# Altium schematic sheet 3_AVIDEO.SchDoc(3_AVIDEO)
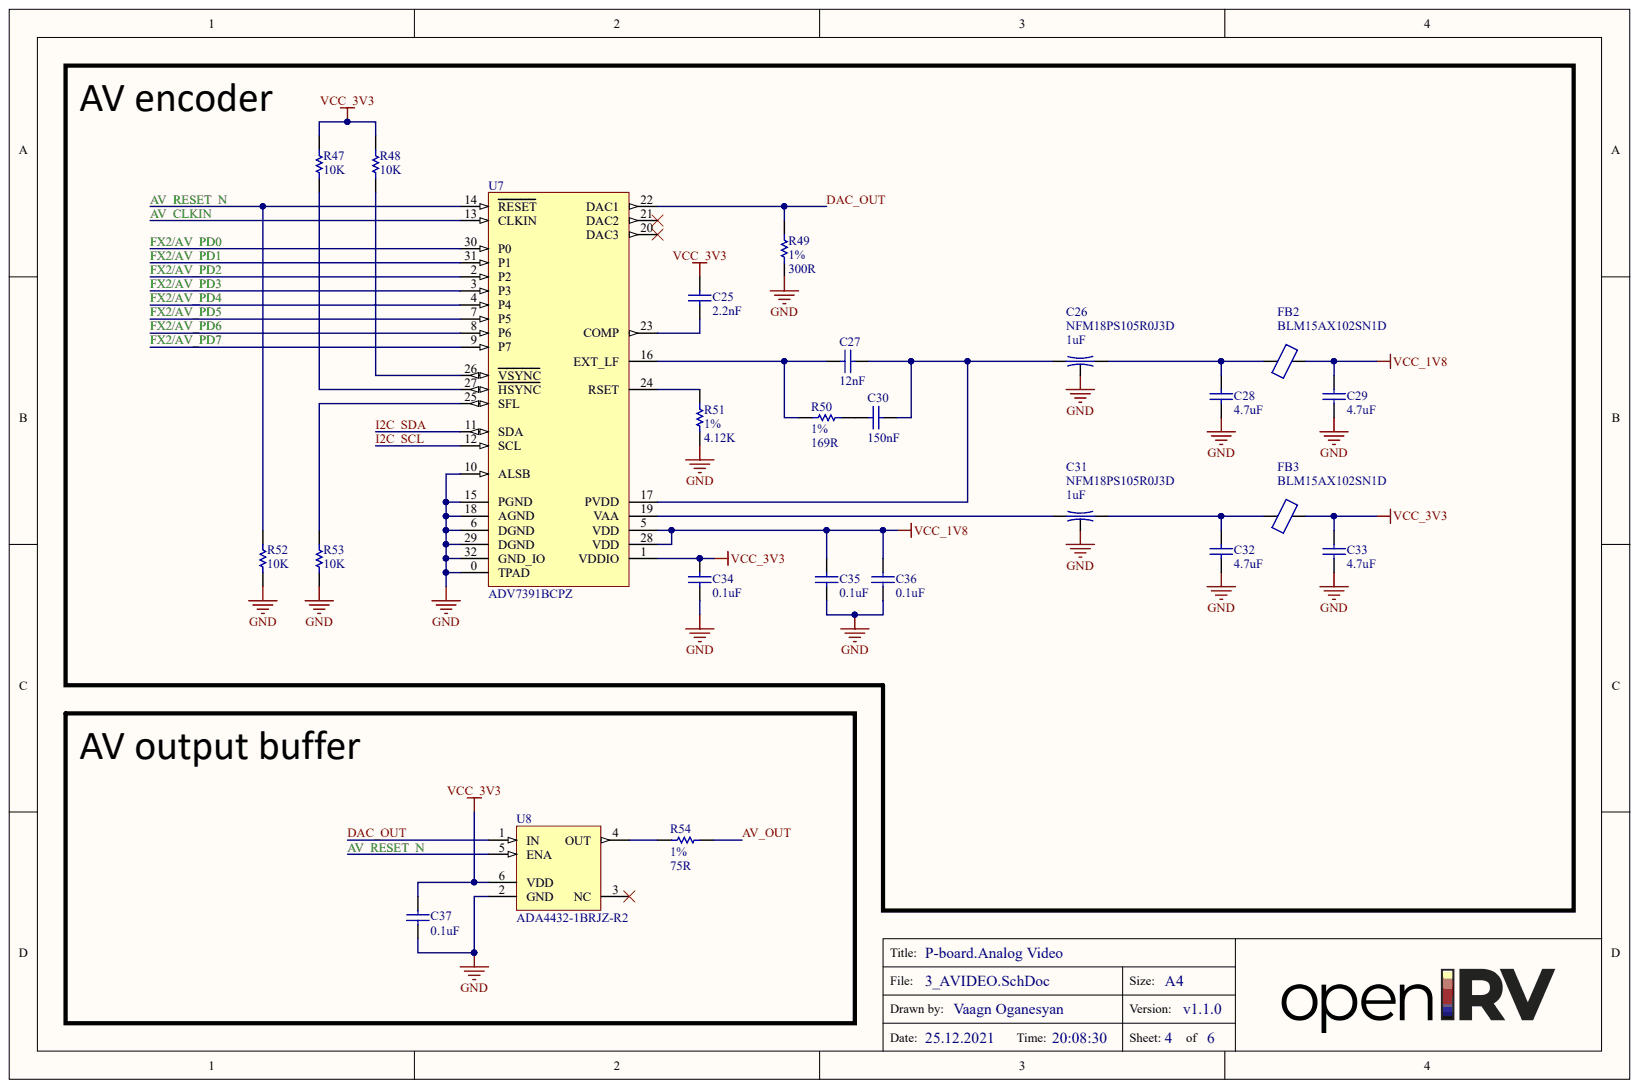
[diagram p0: 3_AVIDEO.SchDoc]

## Parts
C25  2.2nF  [C0603[1608]]
C26  1uF  ManufacturerPartNumber: NFM18PS105R0J3D  [NFM18PS]
C27  12nF  [C0603[1608]]
C28  4.7uF  [C0402[1005]]
C29  4.7uF  [C0402[1005]]
C30  150nF  [C0603[1608]]
C31  1uF  ManufacturerPartNumber: NFM18PS105R0J3D  [NFM18PS]
C32  4.7uF  [C0402[1005]]
C33  4.7uF  [C0402[1005]]
C34  0.1uF  [C0402[1005]]
C35  0.1uF  [C0402[1005]]
C36  0.1uF  [C0402[1005]]
C37  0.1uF  [C0402[1005]]
FB2  BLM15AX102SN1D  [L0402[1005]]
FB3  BLM15AX102SN1D  [L0402[1005]]
R47  10K  [R0402[1005]]
R48  10K  [R0402[1005]]
R49  300R  Tolerance: 1%  [R0603[1608]]
R50  169R  Tolerance: 1%  [R0603[1608]]
R51  4.12K  Tolerance: 1%  [R0603[1608]]
R52  10K  [R0402[1005]]
R53  10K  [R0402[1005]]
R54  75R  Tolerance: 1%  [R0603[1608]]
U7  ADV7391BCPZ  [ADV7391BCPZ]
U8  ADA4432-1BRJZ-R2  [ADA4432-1BRJZ-R2]

## Nets
GND: C26.2 C28.2 C29.2 C31.2 C32.2 C33.2 C34.1 C35.1 C36.1 C37.1 R49.2 R51.2 R52.2 R53.1 U7.0(TPAD) U7.6(DGND) U7.10(ALSB) U7.15(PGND) U7.18(AGND) U7.29(DGND) U7.32(GND_IO) U8.2(GND)
VCC_1V8: C29.1 C35.2 C36.2 FB2.2 U7.5(VDD) U7.28(VDD)
VCC_3V3: C25.2 C33.1 C34.2 C37.2 FB3.2 R47.1 R48.1 U7.1(VDDIO) U8.6(VDD)
AV_CLKIN: U7.13(CLKIN)
AV_OUT: R54.2
AV_RESET_N: R52.1 U7.14(RESET) U8.5(ENA)
DAC_OUT: R49.1 U7.22(DAC1) U8.1(IN)
FX2/AV_PD0: U7.30(P0)
FX2/AV_PD1: U7.31(P1)
FX2/AV_PD2: U7.2(P2)
FX2/AV_PD3: U7.3(P3)
FX2/AV_PD4: U7.4(P4)
FX2/AV_PD5: U7.7(P5)
FX2/AV_PD6: U7.8(P6)
FX2/AV_PD7: U7.9(P7)
I2C_SCL: U7.12(SCL)
I2C_SDA: U7.11(SDA)
NetC25_1: C25.1 U7.23(COMP)
NetC26_1: C26.1 C27.1 C30.1 U7.17(PVDD)
NetC26_3: C26.3 C28.1 FB2.1
NetC27_2: C27.2 R50.1 U7.16(EXT_LF)
NetC30_2: C30.2 R50.2
NetC31_1: C31.1 U7.19(VAA)
NetC31_3: C31.3 C32.1 FB3.1
NetR47_2: R47.2 U7.27(HSYNC)
NetR48_2: R48.2 U7.26(VSYNC)
NetR51_1: R51.1 U7.24(RSET)
NetR53_2: R53.2 U7.25(SFL)
NetR54_1: R54.1 U8.4(OUT)

Not connected: U7 20(DAC3) 21(DAC2); U8 3(NC)

## Library data of the parts
C25 C27 C30 C34-C37 = CAP: Dielectric: X7R; Tolerance: 10%; Voltage: 50V; Component Kind: Standard; Library Name: general.IntLib; Mounting: SMD
  C25: Value: 2.2nF
  C27: Value: 12nF
  C30: Value: 150nF
  C34-C37: Value: 0.1uF
C26 C31 = 3T_CAP: Current: 2A; Tolerance: 20%; Voltage: 6.3V; Component Kind: Standard; Library Name: general.IntLib; Mounting: SMD; Value: 1uF
C28 C29 C32 C33 = CAP: Dielectric: X5R; Tolerance: 10%; Voltage: 6.3V; Component Kind: Standard; Library Name: general.IntLib; Mounting: SMD; Value: 4.7uF
FB2 FB3 = Ferrite_bead: Current: 0.35A; DC resistance: 0R49; Impedance: 1000R; Component Kind: Standard; Library Name: general.IntLib; ManufacturerPartNumber: BLM15AX102SN1D; Mounting: SMD
R47 R48 R52 R53 = RES: Power: 0.06W; Tolerance: 5%; Component Kind: Standard; Library Name: general.IntLib; Mounting: SMD; Value: 10K
R49-R51 R54 = RES: Power: 0.1W; Component Kind: Standard; Library Name: general.IntLib; Mounting: SMD
  R49: Value: 300R
  R50: Value: 169R
  R51: Value: 4.12K
  R54: Value: 75R
U7 = ADV7391BCPZ: 10-bit SD/HD Video Encoder; Component Kind: Standard; Library Name: general.IntLib
U8 = ADA4432-1BRJZ-R2: Component Kind: Standard; Library Name: general.IntLib
